# Altium schematic sheet Pmod_Interfaces.SchDoc(Pmod_Interfaces)
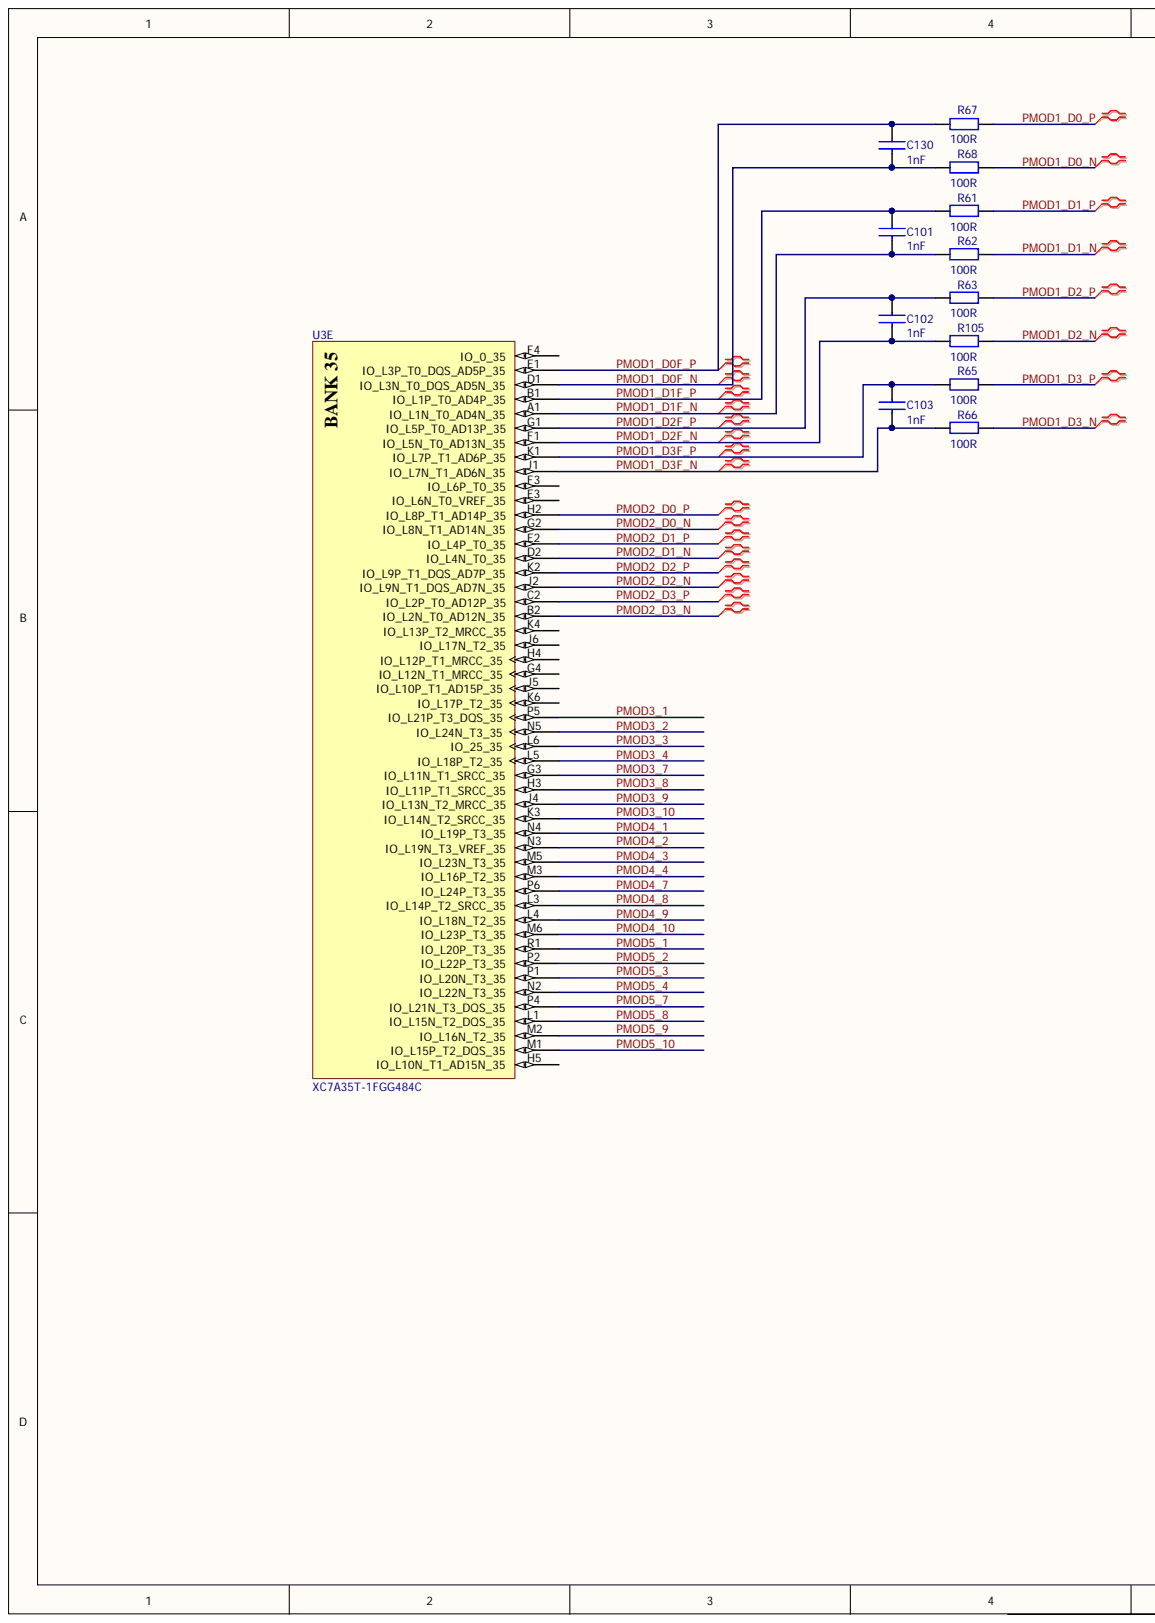
[diagram p0: Pmod_Interfaces.SchDoc, left]
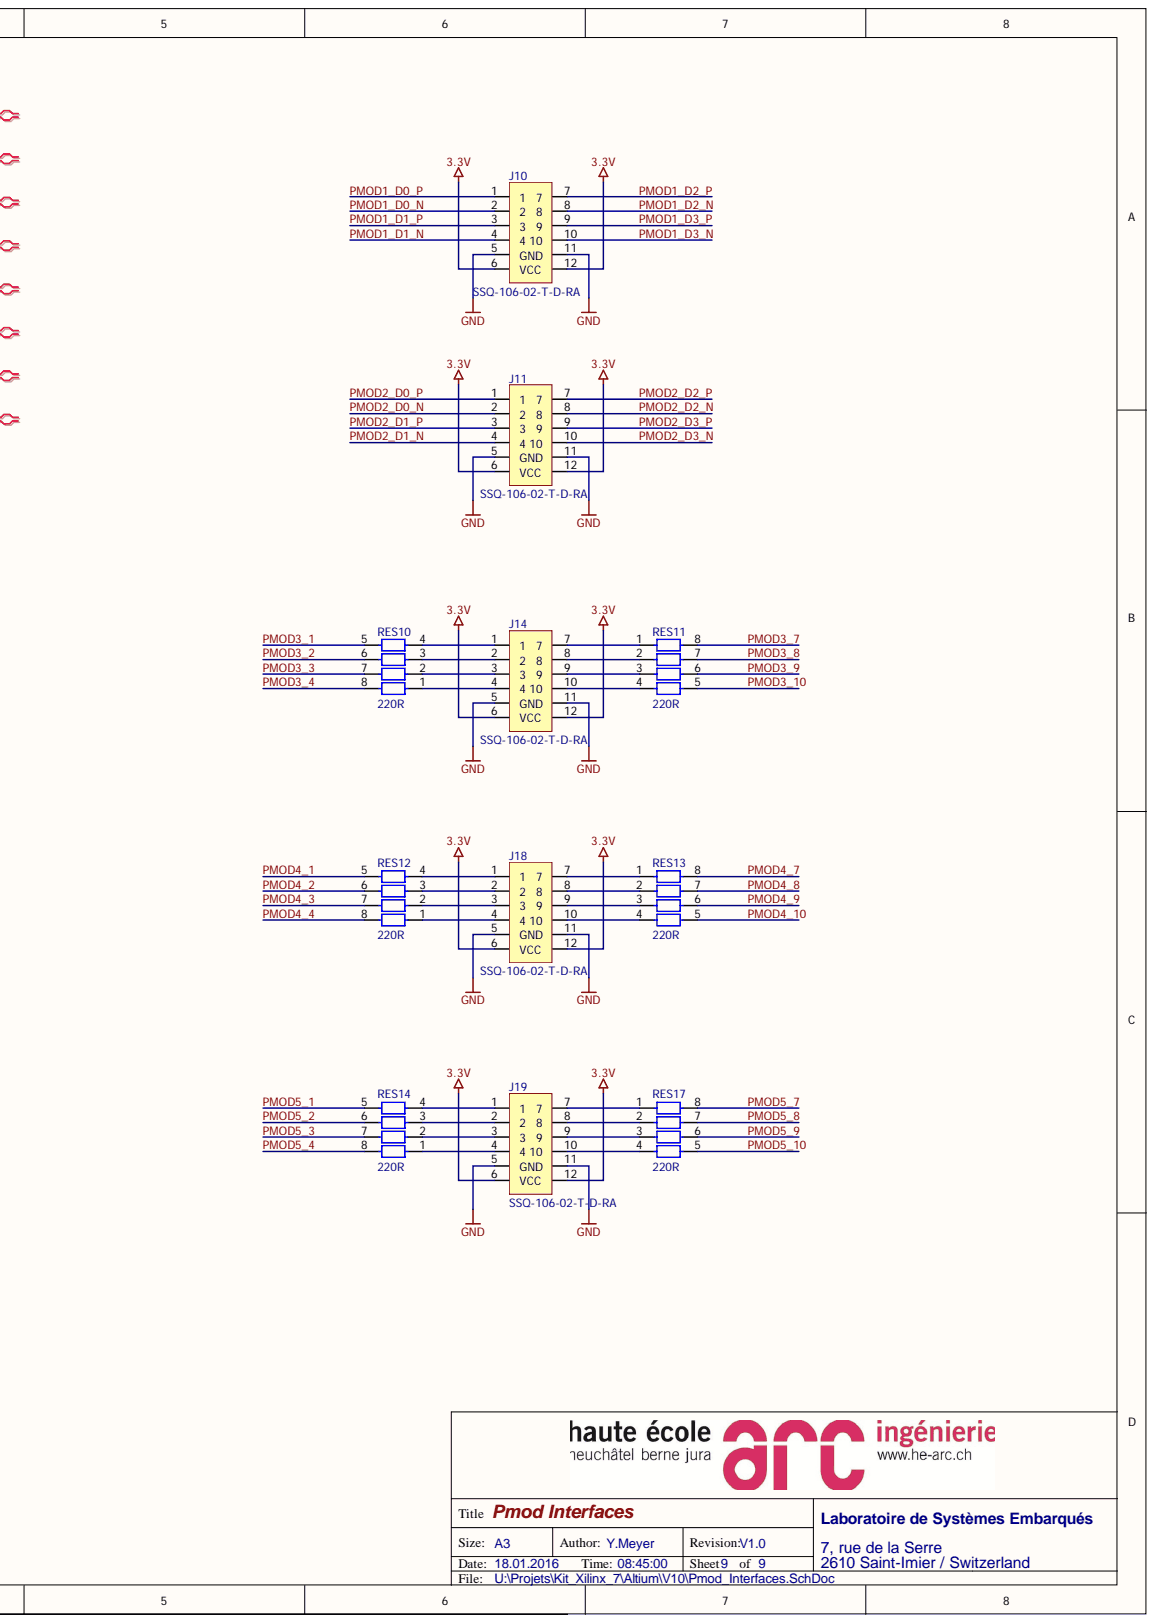
[diagram p1: Pmod_Interfaces.SchDoc, right]

## Parts
C101  value 1nF  [060301]  (hidden comment: C0603C102K5RACTU)
C102  value 1nF  [060301]  (hidden comment: C0603C102K5RACTU)
C103  value 1nF  [060301]  (hidden comment: C0603C102K5RACTU)
C130  value 1nF  [060301]  (hidden comment: C0603C102K5RACTU)
J10  SSQ-106-02-T-D-RA  [CON_PMOD]
J11  SSQ-106-02-T-D-RA  [CON_PMOD]
J14  SSQ-106-02-T-D-RA  [CON_PMOD]
J18  SSQ-106-02-T-D-RA  [CON_PMOD]
J19  SSQ-106-02-T-D-RA  [CON_PMOD]
R61  value 100R  [060301]  (hidden comment: RC0603FR-07100RL)
R62  value 100R  [060301]  (hidden comment: RC0603FR-07100RL)
R63  value 100R  [060301]  (hidden comment: RC0603FR-07100RL)
R65  value 100R  [060301]  (hidden comment: RC0603FR-07100RL)
R66  value 100R  [060301]  (hidden comment: RC0603FR-07100RL)
R67  value 100R  [060301]  (hidden comment: RC0603FR-07100RL)
R68  value 100R  [060301]  (hidden comment: RC0603FR-07100RL)
R105  value 100R  [060301]  (hidden comment: RC0603FR-07100RL)
RES10  value 220R  [4060301]  (hidden comment: YC164-JR-07220RL)
RES11  value 220R  [4060301]  (hidden comment: YC164-JR-07220RL)
RES12  value 220R  [4060301]  (hidden comment: YC164-JR-07220RL)
RES13  value 220R  [4060301]  (hidden comment: YC164-JR-07220RL)
RES14  value 220R  [4060301]  (hidden comment: YC164-JR-07220RL)
RES17  value 220R  [4060301]  (hidden comment: YC164-JR-07220RL)
U3  XC7A35T-1FGG484C  [FGG484]  (part E)

## Nets
3.3V: J10.6(VCC) J10.12 J11.6(VCC) J11.12 J14.6(VCC) J14.12 J18.6(VCC) J18.12 J19.6(VCC) J19.12
GND: J10.5 J10.11(GND) J11.5 J11.11(GND) J14.5 J14.11(GND) J18.5 J18.11(GND) J19.5 J19.11(GND)
PMOD1_D0_N: J10.2 R68.1
PMOD1_D0_P: J10.1 R67.1
PMOD1_D0F_N: C130.2 R68.2 U3.D1(IO_L3N_T0_DQS_AD5N_35)
PMOD1_D0F_P: C130.1 R67.2 U3.E1(IO_L3P_T0_DQS_AD5P_35)
PMOD1_D1_N: J10.4 R62.1
PMOD1_D1_P: J10.3 R61.1
PMOD1_D1F_N: C101.2 R62.2 U3.A1(IO_L1N_T0_AD4N_35)
PMOD1_D1F_P: C101.1 R61.2 U3.B1(IO_L1P_T0_AD4P_35)
PMOD1_D2_N: J10.8 R105.1
PMOD1_D2_P: J10.7 R63.1
PMOD1_D2F_N: C102.2 R105.2 U3.F1(IO_L5N_T0_AD13N_35)
PMOD1_D2F_P: C102.1 R63.2 U3.G1(IO_L5P_T0_AD13P_35)
PMOD1_D3_N: J10.10 R66.1
PMOD1_D3_P: J10.9 R65.1
PMOD1_D3F_N: C103.2 R66.2 U3.J1(IO_L7N_T1_AD6N_35)
PMOD1_D3F_P: C103.1 R65.2 U3.K1(IO_L7P_T1_AD6P_35)
PMOD2_D0_N: J11.2 U3.G2(IO_L8N_T1_AD14N_35)
PMOD2_D0_P: J11.1 U3.H2(IO_L8P_T1_AD14P_35)
PMOD2_D1_N: J11.4 U3.D2(IO_L4N_T0_35)
PMOD2_D1_P: J11.3 U3.E2(IO_L4P_T0_35)
PMOD2_D2_N: J11.8 U3.J2(IO_L9N_T1_DQS_AD7N_35)
PMOD2_D2_P: J11.7 U3.K2(IO_L9P_T1_DQS_AD7P_35)
PMOD2_D3_N: J11.10 U3.B2(IO_L2N_T0_AD12N_35)
PMOD2_D3_P: J11.9 U3.C2(IO_L2P_T0_AD12P_35)
PMOD3_1: RES10.5 U3.P5(IO_L21P_T3_DQS_35)
PMOD3_2: RES10.6 U3.N5(IO_L24N_T3_35)
PMOD3_3: RES10.7 U3.L6(IO_25_35)
PMOD3_4: RES10.8 U3.L5(IO_L18P_T2_35)
PMOD3_7: RES11.8 U3.G3(IO_L11N_T1_SRCC_35)
PMOD3_8: RES11.7 U3.H3(IO_L11P_T1_SRCC_35)
PMOD3_9: RES11.6 U3.J4(IO_L13N_T2_MRCC_35)
PMOD3_10: RES11.5 U3.K3(IO_L14N_T2_SRCC_35)
PMOD4_1: RES12.5 U3.N4(IO_L19P_T3_35)
PMOD4_2: RES12.6 U3.N3(IO_L19N_T3_VREF_35)
PMOD4_3: RES12.7 U3.M5(IO_L23N_T3_35)
PMOD4_4: RES12.8 U3.M3(IO_L16P_T2_35)
PMOD4_7: RES13.8 U3.P6(IO_L24P_T3_35)
PMOD4_8: RES13.7 U3.L3(IO_L14P_T2_SRCC_35)
PMOD4_9: RES13.6 U3.L4(IO_L18N_T2_35)
PMOD4_10: RES13.5 U3.M6(IO_L23P_T3_35)
PMOD5_1: RES14.5 U3.R1(IO_L20P_T3_35)
PMOD5_2: RES14.6 U3.P2(IO_L22P_T3_35)
PMOD5_3: RES14.7 U3.P1(IO_L20N_T3_35)
PMOD5_4: RES14.8 U3.N2(IO_L22N_T3_35)
PMOD5_7: RES17.8 U3.P4(IO_L21N_T3_DQS_35)
PMOD5_8: RES17.7 U3.L1(IO_L15N_T2_DQS_35)
PMOD5_9: RES17.6 U3.M2(IO_L16N_T2_35)
PMOD5_10: RES17.5 U3.M1(IO_L15P_T2_DQS_35)
NetJ14_1: J14.1 RES10.4
NetJ14_2: J14.2 RES10.3
NetJ14_3: J14.3 RES10.2
NetJ14_4: J14.4 RES10.1
NetJ14_7: J14.7 RES11.1
NetJ14_8: J14.8 RES11.2
NetJ14_9: J14.9 RES11.3
NetJ14_10: J14.10 RES11.4
NetJ18_1: J18.1 RES12.4
NetJ18_2: J18.2 RES12.3
NetJ18_3: J18.3 RES12.2
NetJ18_4: J18.4 RES12.1
NetJ18_7: J18.7 RES13.1
NetJ18_8: J18.8 RES13.2
NetJ18_9: J18.9 RES13.3
NetJ18_10: J18.10 RES13.4
NetJ19_1: J19.1 RES14.4
NetJ19_2: J19.2 RES14.3
NetJ19_3: J19.3 RES14.2
NetJ19_4: J19.4 RES14.1
NetJ19_7: J19.7 RES17.1
NetJ19_8: J19.8 RES17.2
NetJ19_9: J19.9 RES17.3
NetJ19_10: J19.10 RES17.4

Not connected: U3 E3(IO_L6N_T0_VREF_35) F3(IO_L6P_T0_35) F4(IO_0_35) G4(IO_L12N_T1_MRCC_35) H4(IO_L12P_T1_MRCC_35) H5(IO_L10N_T1_AD15N_35) J5(IO_L10P_T1_AD15P_35) J6(IO_L17N_T2_35) K4(IO_L13P_T2_MRCC_35) K6(IO_L17P_T2_35)

## Library data of the parts
C101-C103 C130 = CAPA: CAP CER 1000PF 50V X7R 0603; Component Kind: Standard; Supplier 1: Digi-Key; Supplier Part Number 1: 399-1082-1-ND; Library Name: EISI.SchLib
J10 J11 J14 J18 J19 = CON_PMOD: Connector Receptacle 12 Position 0.100" (2.54mm) Tin Through Hole, Right Angle; Manufacturer 1: Samtec; Component Kind: Standard; Manufacturer Part Number 1: SSQ-106-02-T-D-RA; Supplier 1: Samtec; Supplier Part Number 1: SSQ-106-02-T-D-RA; Supplier Subtotal 1: 164; Supplier Unit Price 1: 0.65; Library Name: EISI.SchLib
R61-R63 R65-R68 R105 = RES: RES SMD 100 OHM 1% 1/10W 0603; Component Kind: Standard; Supplier 1: Digi-Key; Supplier Part Number 1: 311-100HRCT-ND; Library Name: EISI.SchLib
RES10-RES14 RES17 = RESEAU_4R: RES ARRAY 4 RES 220 OHM 1206; Component Kind: Standard; Supplier 1: Digi-Key; Supplier Part Number 1: YC164J-220TR-ND; Library Name: EISI.SchLib
U3 = XC7A35T-1FGG484C: Artix-7 FPGA, 250 User I/Os, 4 GTP, 484-Ball BGA, Speed Grade 1, Commercial Grade, Pb-Free; Manufacturer 1: Xilinx; Component Kind: Standard; Library Name: KitXilinx7.SCHLIB; Manufacturer Part Number 1: XC7A35T-1FGG484C; Supplier 1: Avnet Express; Supplier Part Number 1: XC7A35T-1FGG484C; Supplier Subtotal 1: 2140; Supplier Unit Price 1: 52.1952
